# Altium schematic sheet Status_LED.SchDoc(Status_LED)
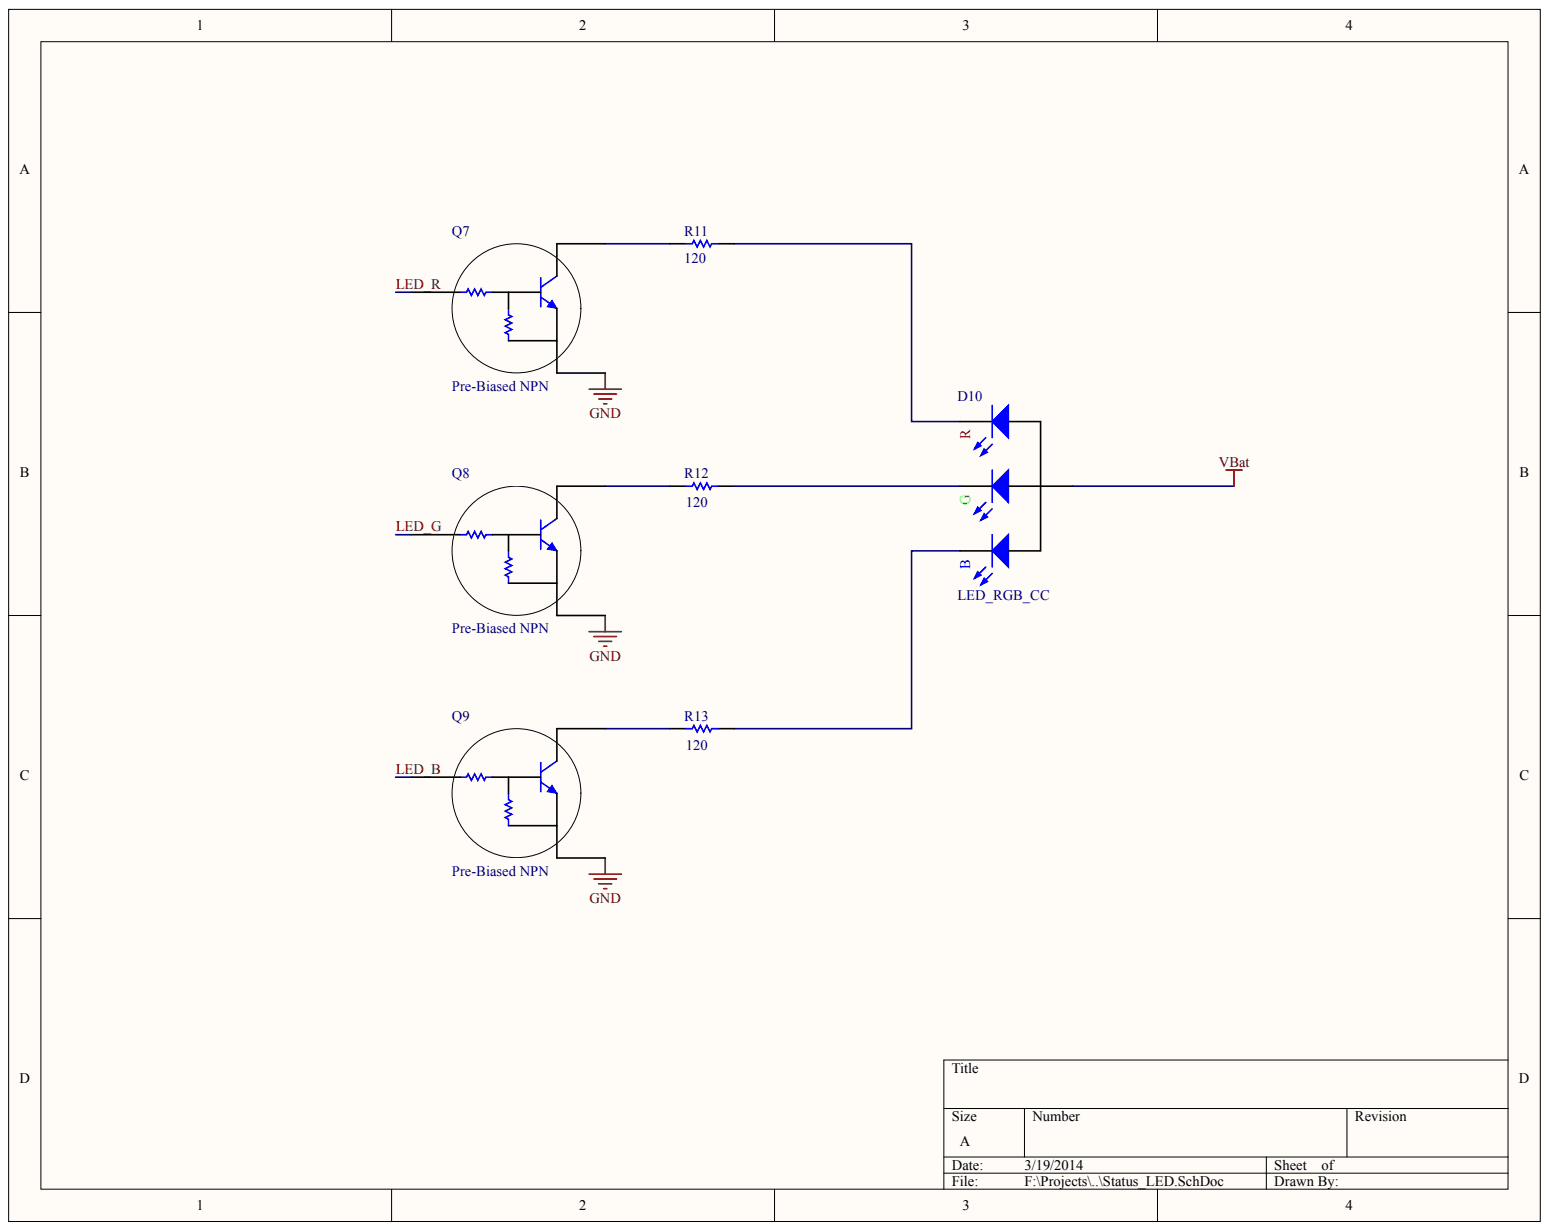
[diagram p0: Status_LED.SchDoc]

## Parts
D10  LED_RGB_CC
Q7  Pre-Biased NPN
Q8  Pre-Biased NPN
Q9  Pre-Biased NPN
R11  value 120  (hidden comment: Resistor)
R12  value 120  (hidden comment: Resistor)
R13  value 120  (hidden comment: Resistor)

## Nets
GND: Q7.2 Q8.2 Q9.2
VBAT: D10.1
LED_B: Q9.1
LED_G: Q8.1
LED_R: Q7.1
NetD10_2: D10.2 R11.2
NetD10_3: D10.3 R12.2
NetD10_4: D10.4 R13.2
NetQ7_3: Q7.3 R11.1
NetQ8_3: Q8.3 R12.1
NetQ9_3: Q9.3 R13.1

## Library data of the parts
Q7-Q9 = (no symbol name): Manufacturer Part No: DTD743EETL; Vendor Part No: DTD743EETLTR-ND; Vendor: Digikey
D10 R11-R13 = (no symbol name)
  R11-R13: Published: 8-Jun-2000; LatestRevisionDate: 17-Jul-2002; LatestRevisionNote: Re-released for DXP Platform.; PackageReference: AXIAL-0.3; Publisher: Altium Limited
